# Altium schematic sheet imp002-evb-core.SchDoc
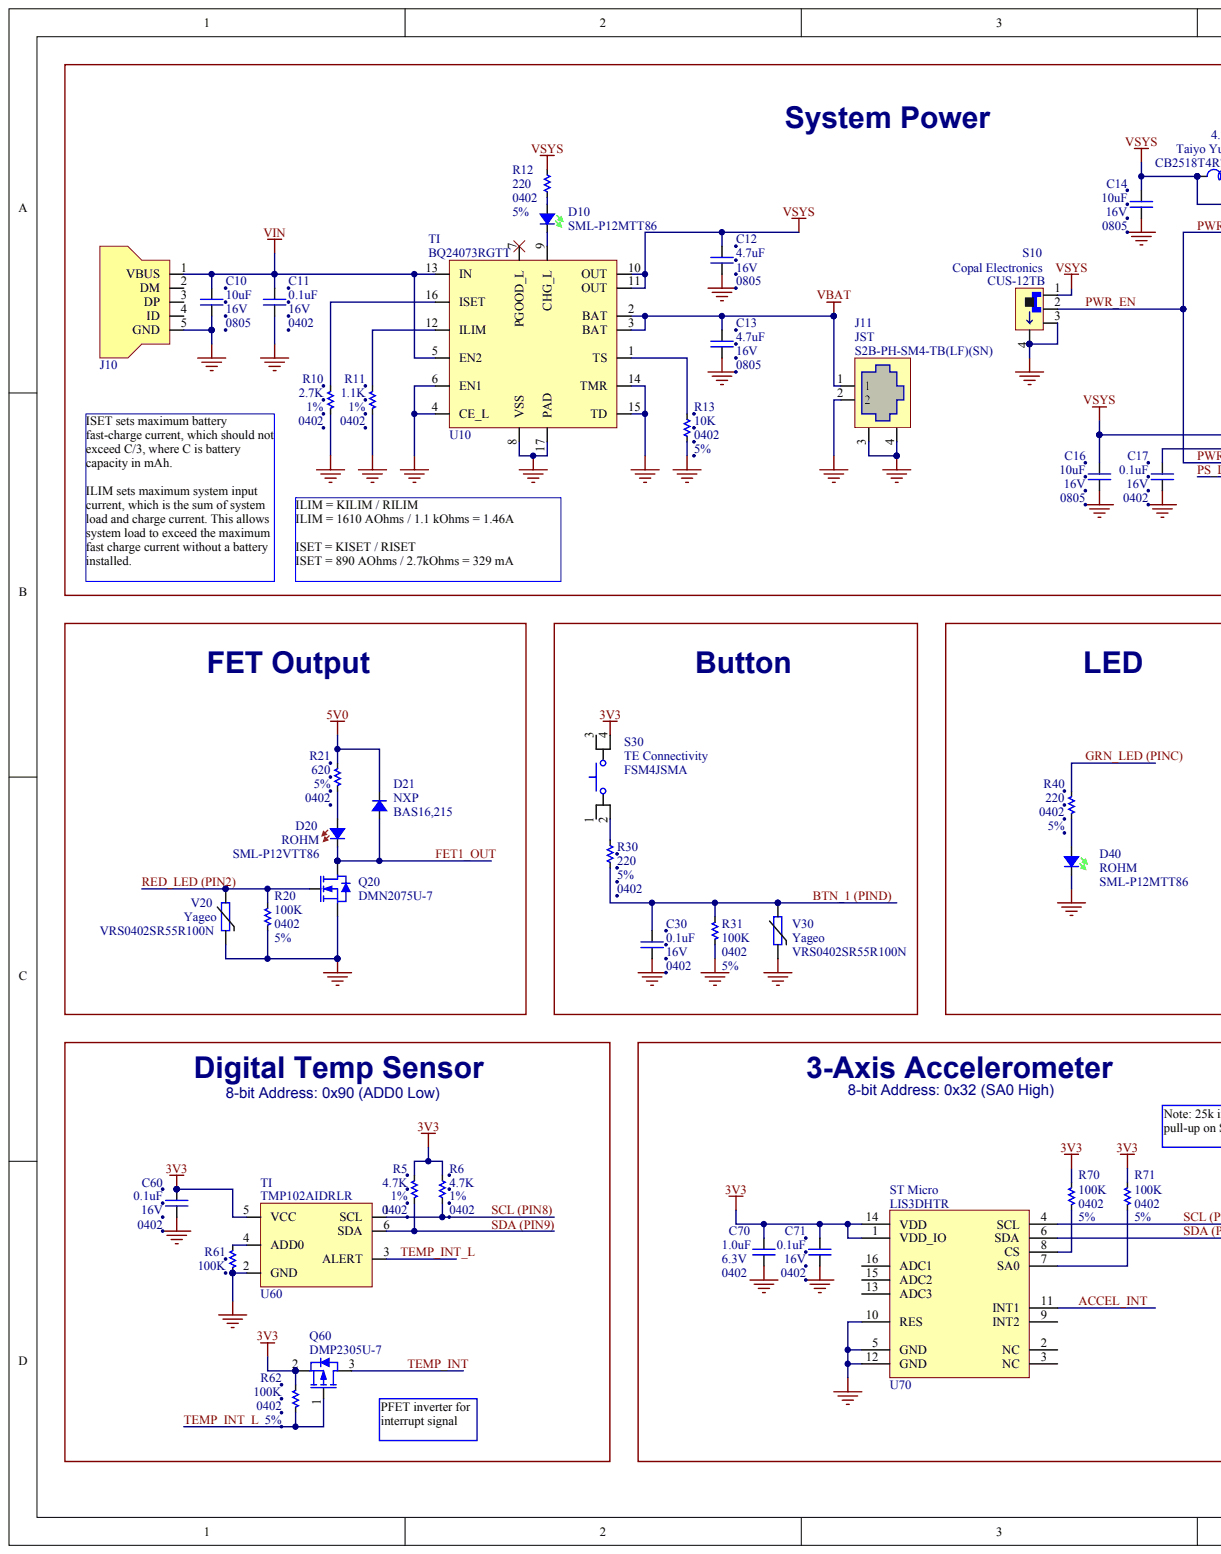
[diagram p0: imp002-evb-core.SchDoc, left]
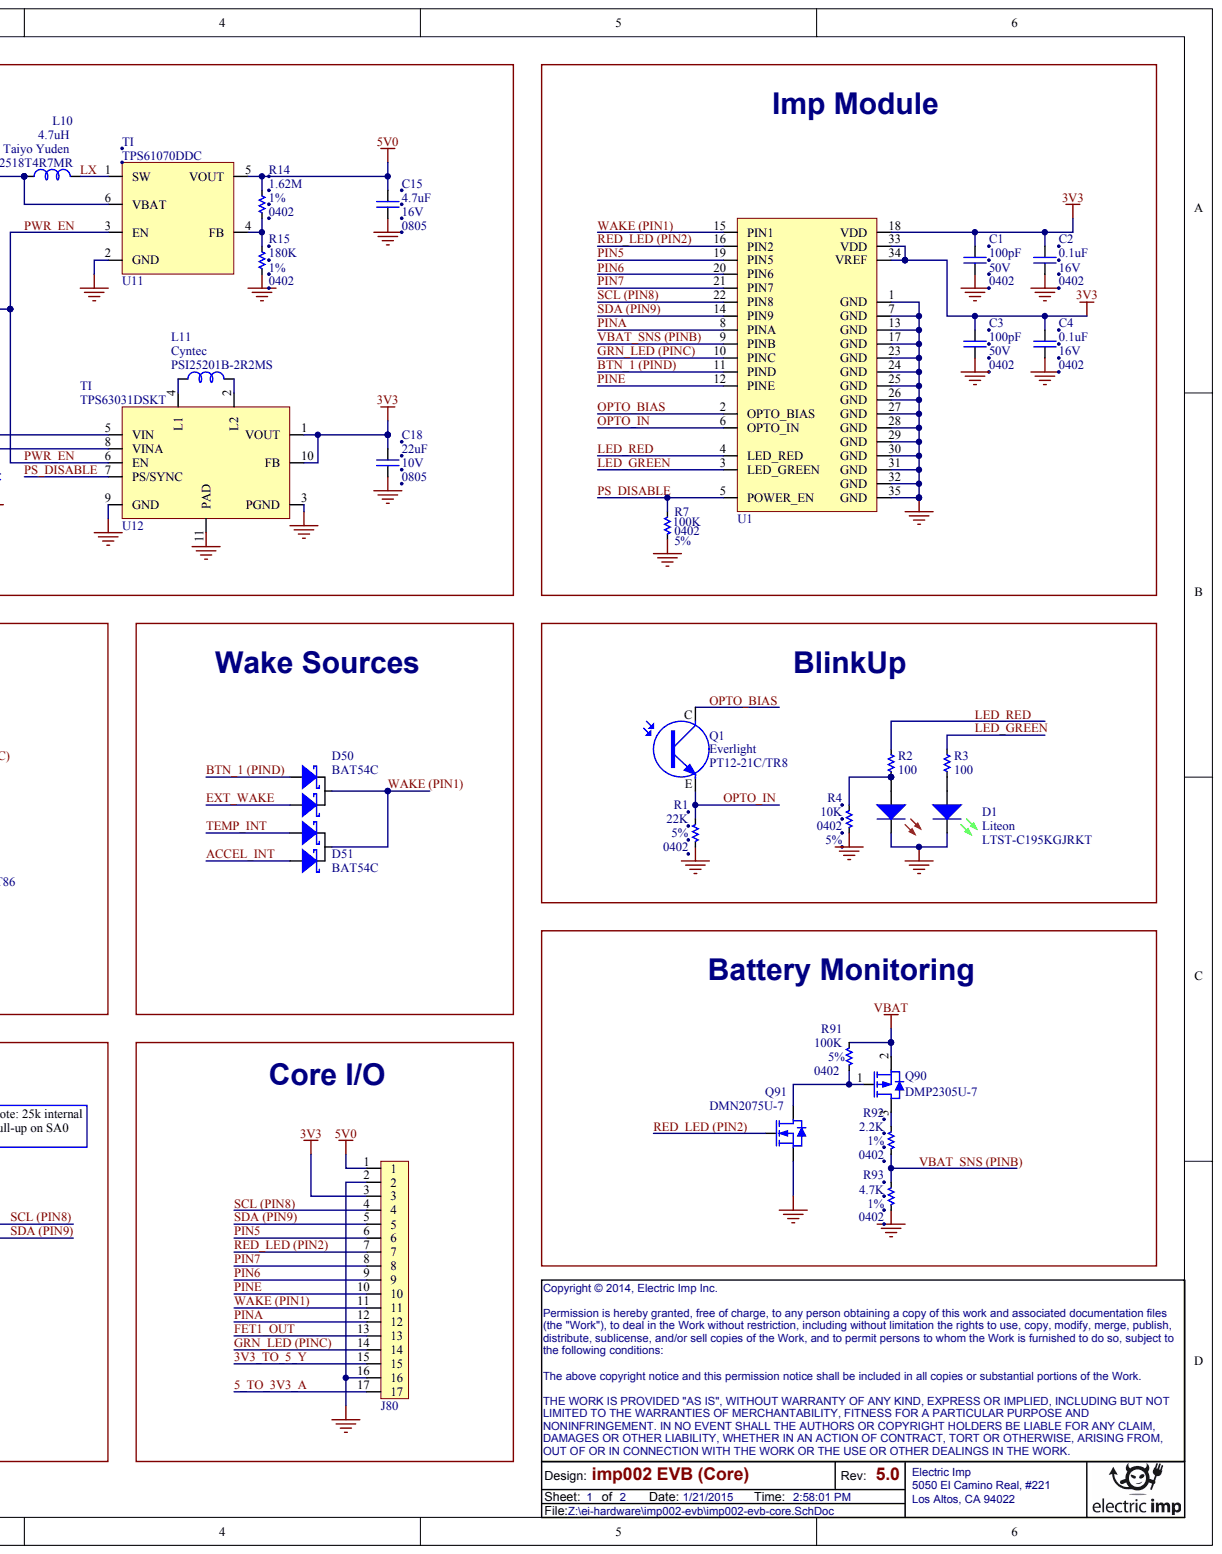
[diagram p1: imp002-evb-core.SchDoc, right]

## Parts
C1  value 100pF  Voltage: 50V  Package: 0402  (hidden comment: Ceramic Capacitor)
C2  value 0.1uF  Voltage: 16V  Package: 0402  (hidden comment: Ceramic Capacitor)
C3  value 100pF  Voltage: 50V  Package: 0402  (hidden comment: Ceramic Capacitor)
C4  value 0.1uF  Voltage: 16V  Package: 0402  (hidden comment: Ceramic Capacitor)
C10  value 10uF  Voltage: 16V  Package: 0805  (hidden comment: Ceramic Capacitor)
C11  value 0.1uF  Voltage: 16V  Package: 0402  (hidden comment: Ceramic Capacitor)
C12  value 4.7uF  Voltage: 16V  Package: 0805  (hidden comment: Ceramic Capacitor)
C13  value 4.7uF  Voltage: 16V  Package: 0805  (hidden comment: Ceramic Capacitor)
C14  value 10uF  Voltage: 16V  Package: 0805  (hidden comment: Ceramic Capacitor)
C15  value 4.7uF  Voltage: 16V  Package: 0805  (hidden comment: Ceramic Capacitor)
C16  value 10uF  Voltage: 16V  Package: 0805  (hidden comment: Ceramic Capacitor)
C17  value 0.1uF  Voltage: 16V  Package: 0402  (hidden comment: Ceramic Capacitor)
C18  value 22uF  Voltage: 10V  Package: 0805  (hidden comment: Ceramic Capacitor)
C30  value 0.1uF  Voltage: 16V  Package: 0402  (hidden comment: Ceramic Capacitor)
C60  value 0.1uF  Voltage: 16V  Package: 0402  (hidden comment: Ceramic Capacitor)
C70  value 1.0uF  Voltage: 6.3V  Package: 0402  (hidden comment: Ceramic Capacitor)
C71  value 0.1uF  Voltage: 16V  Package: 0402  (hidden comment: Ceramic Capacitor)
D1  Manufacturer: Liteon  Manufacturer Part Number: LTST-C195KGJRKT  (hidden comment: LED Red and Green)
D10  Package: 0402  Manufacturer Part Number: SML-P12MTT86  (hidden comment: LED Green)
D20  Manufacturer: ROHM  Manufacturer Part Number: SML-P12VTT86  (hidden comment: LED Red)
D21  Manufacturer: NXP  Manufacturer Part Number: BAS16,215  (hidden comment: Diode)
D40  Manufacturer: ROHM  Manufacturer Part Number: SML-P12MTT86  (hidden comment: LED Green)
D50  Manufacturer Part Number: BAT54C  (hidden comment: Dual Schottky Diode)
D51  Manufacturer Part Number: BAT54C  (hidden comment: Dual Schottky Diode)
J10  USB Mini/Micro-B  (value not drawn)
J11  Manufacturer: JST  Manufacturer Part Number: S2B-PH-SM4-TB(LF)(SN)  (hidden comment: JST Connector)
J80  Header  (value not drawn)
L10  value 4.7uH  Manufacturer: Taiyo Yuden  Manufacturer Part Number: CB2518T4R7MR  (hidden comment: Inductor)
L11  Manufacturer: Cyntec  Manufacturer Part Number: PSI25201B-2R2MS  (hidden comment: Inductor)
Q1  Manufacturer: Everlight  Manufacturer Part Number: PT12-21C/TR8  (hidden comment: PHOTOTRANSISTOR)
Q20  Manufacturer Part Number: DMN2075U-7  (hidden comment: N-Channel MOSFET)
Q60  PFET  Manufacturer Part Number: DMP2305U-7
Q90  Manufacturer Part Number: DMP2305U-7  (hidden comment: PFET)
Q91  Manufacturer Part Number: DMN2075U-7  (hidden comment: N-Channel MOSFET)
R1  value 22K  Package: 0402  Tolerance: 5%  (hidden comment: Resistor)
R2  value 100  (hidden comment: Resistor)
R3  value 100  (hidden comment: Resistor)
R4  value 10K  Package: 0402  Tolerance: 5%  (hidden comment: Resistor)
R5  value 4.7K  Package: 0402  Tolerance: 1%  (hidden comment: Resistor)
R6  value 4.7K  Package: 0402  Tolerance: 1%  (hidden comment: Resistor)
R7  value 100K  Package: 0402  Tolerance: 5%  (hidden comment: Resistor)
R10  value 2.7K  Package: 0402  Tolerance: 1%  (hidden comment: Resistor)
R11  value 1.1K  Package: 0402  Tolerance: 1%  (hidden comment: Resistor)
R12  value 220  Package: 0402  (hidden comment: Resistor)
R13  value 10K  Package: 0402  Tolerance: 5%  (hidden comment: Resistor)
R14  value 1.62M  Package: 0402  Tolerance: 1%  (hidden comment: Resistor)
R15  value 180K  Package: 0402  Tolerance: 1%  (hidden comment: Resistor)
R20  value 100K  Package: 0402  Tolerance: 5%  (hidden comment: Resistor)
R21  value 620  Package: 0402  Tolerance: 5%  (hidden comment: Resistor)
R30  value 220  Package: 0402  Tolerance: 5%  (hidden comment: Resistor)
R31  value 100K  Package: 0402  Tolerance: 5%  (hidden comment: Resistor)
R40  value 220  Package: 0402  Tolerance: 5%  (hidden comment: Resistor)
R61  value 100K  (hidden comment: Resistor)
R62  value 100K  Package: 0402  Tolerance: 5%  (hidden comment: Resistor)
R70  value 100K  Package: 0402  Tolerance: 5%  (hidden comment: Resistor)
R71  value 100K  Package: 0402  Tolerance: 5%  (hidden comment: Resistor)
R91  value 100K  Package: 0402  Tolerance: 5%  (hidden comment: Resistor)
R92  value 2.2K  Package: 0402  Tolerance: 1%  (hidden comment: Resistor)
R93  value 4.7K  Package: 0402  Tolerance: 1%  (hidden comment: Resistor)
S10  Manufacturer: Copal Electronics  Manufacturer Part Number: CUS-12TB  (hidden comment: Slide Switch)
S30  Manufacturer: TE Connectivity  Manufacturer Part Number: FSM4JSMA  (hidden comment: Push Button)
U1  imp module  (value not drawn)
U10  BQ24073  Manufacturer: TI  Manufacturer Part Number: BQ24073RGTT
U11  Manufacturer: TI  Manufacturer Part Number: TPS61070DDC  (hidden comment: Boost)
U12  TPS63031  Manufacturer: TI  Manufacturer Part Number: TPS63031DSKT
U60  TMP102  Manufacturer: TI  Manufacturer Part Number: TMP102AIDRLR
U70  LIS3DHTR  Manufacturer: ST Micro
V20  Manufacturer: Yageo  Manufacturer Part Number: VRS0402SR55R100N  (hidden comment: VARISTOR)
V30  Manufacturer: Yageo  Manufacturer Part Number: VRS0402SR55R100N  (hidden comment: VARISTOR)

## Nets
3V3: C1.2 C2.2 C3.2 C4.2 C18.2 C60.2 C70.2 C71.2 J80.3 Q60.2 R5.1 R6.1 R62.1 R70.2 R71.2 S30.4 U1.18(VDD) U1.33(VDD) U1.34(VREF) U12.1(VOUT) U12.10(FB) U60.5(VCC) U70.1(VDD_IO) U70.14(VDD)
5V0: C15.2 D21.K J80.1 R14.1 R21.1 U11.5(VOUT)
GND: C1.1 C2.1 C3.1 C4.1 C10.1 C11.1 C12.1 C13.1 C14.1 C15.1 C16.1 C17.1 C18.1 C30.1 C60.1 C70.1 C71.1 D1.KG D1.KR D40.K J10.5(GND) J11.2 J11.3 J11.4 J80.2 J80.16 Q20.2 Q91.2 R1.2 R4.2 R7.1 R10.2 R11.2 R13.2 R15.2 R20.1 R31.1 R61.2 R93.2 S10.3 S10.4 U1.1(GND) U1.7(GND) U1.13(GND) U1.17(GND) U1.23(GND) U1.24(GND) U1.25(GND) U1.26(GND) U1.27(GND) U1.28(GND) U1.29(GND) U1.30(GND) U1.31(GND) U1.32(GND) U1.35(GND) U10.4(CE_L) U10.6(EN1) U10.8(VSS) U10.14(TMR) U10.15(TD) U10.17(PAD) U11.2(GND) U12.3(PGND) U12.9(GND) U12.11(PAD) U60.2(GND) U70.5(GND) U70.10(RES) U70.12(GND) V20.2 V30.2
VBAT: C13.2 J11.1 Q90.2 R91.1 U10.2(BAT) U10.3(BAT)
VIN: C10.2 C11.2 J10.1(VBUS) U10.5(EN2) U10.13(IN)
VSYS: C12.2 C14.2 C16.2 L10.1 R12.2 S10.1 U10.10(OUT) U10.11(OUT) U11.6(VBAT) U12.5(VIN)
3V3_TO_5_Y: J80.15
5_TO_3V3_A: J80.17
ACCEL_INT: D51.2 U70.11(INT1)
BTN_1 (PIND): C30.2 D50.1 R30.2 R31.2 U1.11(PIND) V30.1
EXT_WAKE: D50.2
FET1_OUT: D20.K D21.A J80.13 Q20.3
GRN_LED (PINC): J80.14 R40.1 U1.10(PINC)
LED_GREEN: R3.1 U1.3(LED_GREEN)
LED_RED: R2.1 U1.4(LED_RED)
LX: L10.2 U11.1(SW)
OPTO_BIAS: Q1.C U1.2(OPTO_BIAS)
OPTO_IN: Q1.E R1.1 U1.6(OPTO_IN)
PIN5: J80.6 U1.19(PIN5)
PIN6: J80.9 U1.20(PIN6)
PIN7: J80.8 U1.21(PIN7)
PINA: J80.12 U1.8(PINA)
PINE: J80.10 U1.12(PINE)
PS_DISABLE: R7.2 U1.5(POWER_EN) U12.7(PS/SYNC)
PWR_EN: S10.2 U11.3(EN) U12.6(EN)
RED_LED (PIN2): J80.7 Q20.1 Q91.1 R20.2 U1.16(PIN2) V20.1
SCL (PIN8): J80.4 R6.2 U1.22(PIN8) U60.1(SCL) U70.4(SCL)
SDA (PIN9): J80.5 R5.2 U1.14(PIN9) U60.6(SDA) U70.6(SDA)
TEMP_INT: D51.1 Q60.3
TEMP_INT_L: Q60.1 R62.2 U60.3(ALERT)
VBAT_SNS (PINB): R92.2 R93.1 U1.9(PINB)
WAKE (PIN1): D50.3 D51.3 J80.11 U1.15(PIN1)
NetC17_2: C17.2 U12.8(VINA)
NetD1_AG: D1.AG R3.2
NetD1_AR: D1.AR R2.2 R4.1
NetD10_A: D10.A R12.1
NetD10_K: D10.K U10.9(CHG_L)
NetD20_A: D20.A R21.2
NetD40_A: D40.A R40.2
NetL11_1: L11.1 U12.4(L1)
NetL11_2: L11.2 U12.2(L2)
NetQ90_1: Q90.1 Q91.3 R91.2
NetQ90_3: Q90.3 R92.1
NetR10_1: R10.1 U10.16(ISET)
NetR11_1: R11.1 U10.12(ILIM)
NetR13_1: R13.1 U10.1(TS)
NetR14_2: R14.2 R15.1 U11.4(FB)
NetR30_1: R30.1 S30.2
NetR61_1: R61.1 U60.4(ADD0)
NetR70_1: R70.1 U70.8(CS)
NetR71_1: R71.1 U70.7(SA0)

Not connected: J10 2(DM) 3(DP) 4(ID); S30 1 3; U10 7(PGOOD_L); U70 2(NC) 3(NC) 9(INT2) 13(ADC3) 15(ADC2) 16(ADC1)

## Library data of the parts
C1 C3 = (no symbol name): Part Number: CAPC00043; Manufacturer: Yageo; Manufacturer Part Number: CC0402JRNPO9BN101; Tolerance: 5%; Dielectric: C0G; Manufacturer 2: Samsung; Manufacturer Part Number 2: CL05C101JB5NNNC; Supplier 1: Digi-Key; Supplier Part Number 1: 311-1024-1-ND; Supplier Price 1: 0.00324; Supplier 2: Digi-Key; Supplier Part Number 2: 1276-1025-1-ND; Supplier Price 2: 0.00324; Stock Quantity: 500; Stock Date: 3/12/2013
C2 C4 C11 C17 C30 C60 C71 = (no symbol name): Part Number: CAPC00025; Manufacturer: Samsung; Manufacturer Part Number: CL05B104KO5NNNC; Tolerance: 10%; Dielectric: X7R; Manufacturer 2: Taiyo Yuden; Manufacturer Part Number 2: EMK105B7104KV-F; Supplier 1: Digi-Key; Supplier Part Number 1: 1276-1001-1-ND; Supplier Price 1: 0.0039; Supplier 2: Digi-Key; Supplier Part Number 2: 587-1451-1-ND; Supplier Price 2: 0.0045; Stock Quantity: 1000; Stock Date: 3/12/2013
C10 C14 C16 = (no symbol name): Part Number: CAPC00037; Manufacturer: Samsung; Manufacturer Part Number: CL21A106KOQNNNE; Tolerance: 10%; Dielectric: X5R; Manufacturer 2: Taiyo Yuden; Manufacturer Part Number 2: EMK212BJ106KG-T; Supplier 1: Digi-Key; Supplier Part Number 1: 1276-1096-1-ND; Supplier Price 1: 0.0385; Supplier 2: Digi-Key; Supplier Part Number 2: 587-1295-1-ND; Supplier Price 2: 0.05355; Stock Quantity: 1000; Stock Date: 3/12/2013
C12 C13 C15 = (no symbol name): Part Number: CAPC00034; Manufacturer: Taiyo Yuden; Manufacturer Part Number: EMK212BJ475KG-T; Tolerance: 10%; Dielectric: X5R; Manufacturer 2: TDK; Manufacturer Part Number 2: C2012X5R1C475K/1.25; Supplier 1: Digi-Key; Supplier Part Number 1: 587-1294-1-ND; Supplier Price 1: 0.051; Supplier 2: Digi-Key; Supplier Part Number 2: 445-7640-1-ND; Supplier Price 2: 0.051; Stock Quantity: 1000; Stock Date: 3/12/2013
C18 = (no symbol name): Part Number: CAPC00107; Manufacturer: TDK; Manufacturer Part Number: C2012X5R1A226M125AB; Tolerance: 20%; Dielectric: X5R; Manufacturer 2: Samsung; Manufacturer Part Number 2: CL21A226MPQNNNE; Supplier 1: Digi-Key; Supplier Part Number 1: 445-7665-1-ND; Supplier Price 1: 0.1495; Supplier 2: Digi-Key; Supplier Part Number 2: 1276-2910-1-ND; Supplier Price 2: 0.1495
C70 = (no symbol name): Part Number: CAPC00005; Manufacturer: Murata; Manufacturer Part Number: GRM155R60J105KE19D; Tolerance: 10%; Dielectric: X5R; Supplier 1: Digi-Key; Supplier Part Number 1: 490-1320-1-ND; Supplier Price 1: 0.0087; Manufacturer 2: Samsung; Manufacturer Part Number 2: CL05A105KQ5NNNC; Supplier 2: Digi-Key; Supplier Part Number 2: 1276-1010-1-ND; Supplier Price 2: 0.009; Stock Date: 3/12/2013; Stock Quantity: 1000
D1 = (no symbol name): Part Number: LED00012; Color: Red/Green; Millicandela Rating (min): 25mcd, 35mcd; Voltage - Forward (Vf) Typ: 2V, 2V; Current - Test: 20mA; Wavelength - Dominant: 631nm, 571nm; Wavelength - Peak: 639nm, 574nm; Viewing Angle: 130°; Lens Type: Clear; Package: SMT; Supplier 1: Digi-Key; Supplier Part Number 1: 160-1452-1-ND; Supplier Price 1: 0.1206; Stock Quantity: 4; Stock Date: 2/27/2013; ComponentLink1URL: http://media.digikey.com/pdf/Data%20Sheets/Lite-On%20PDFs/LTST-C195KGJRKT.pdf
D10 = (no symbol name): Part Number: LED00034; Manufacturer: ROHM; Millicandela Rating (min): 35mcd; Voltage - Forward (Vf) Typ: 2.2V; Current - Test: 20mA; Wavelength - Dominant: 572nm; Viewing Angle: 120°; Lens Type: Clear; Color: Green; Wavelength - Peak: -; Supplier 1: Digi-Key; Supplier Part Number 1: 511-1591-1-ND; Supplier Price 1: 0.126; Stock Quantity: 9; Stock Date: 7/15/2013; ComponentLink1URL: http://www.digikey.com/product-detail/en/SML-P12MTT86/511-1591-1-ND/1643123
D20 = (no symbol name): Part Number: LED00033; Millicandela Rating (min): 60mcd; Voltage - Forward (Vf) Typ: 2V; Current - Test: 20mA; Wavelength - Dominant: 630nm; Viewing Angle: 120°; Lens Type: Clear; Package: 0402; Color: Red; Wavelength - Peak: -; Supplier 1: Digi-Key; Supplier Part Number 1: 511-1594-1-ND; Supplier Price 1: 0.126; Stock Quantity: 9; Stock Date: 7/15/2013; ComponentLink1URL: http://www.digikey.com/product-detail/en/SML-P12VTT86/511-1594-1-ND/1643126
D21 = (no symbol name): Part Number: DIODE00003; Voltage - Forward (Vf) (Max) @ If: 1.25V @150mA; Current - Forward DC: 215mA; Current - Reverse Leakage @ Vr: 0.5uA @ 80V; Continuous Reverse Voltage: 100V; Power - Max: 250mW; Package: SOT23; Supplier 1: Digi-Key; Supplier Part Number 1: 568-1596-1-ND; Supplier Price 1: 0.02688; Stock Quantity: 25; Stock Date: 3/4/2013; ComponentLink1URL: http://www.nxp.com/documents/data_sheet/BAS16_SER.pdf
D40 = (no symbol name): Part Number: LED00034; Millicandela Rating (min): 35mcd; Voltage - Forward (Vf) Typ: 2.2V; Current - Test: 20mA; Wavelength - Dominant: 572nm; Viewing Angle: 120°; Lens Type: Clear; Package: 0402; Color: Green; Wavelength - Peak: -; Supplier 1: Digi-Key; Supplier Part Number 1: 511-1591-1-ND; Supplier Price 1: 0.126; Stock Quantity: 9; Stock Date: 7/15/2013; ComponentLink1URL: http://www.digikey.com/product-detail/en/SML-P12MTT86/511-1591-1-ND/1643123
D50 D51 = (no symbol name): Part Number: SCHOTTKY00009; Manufacturer: Fairchild; Diode Type: Schottky, Dual; Voltage - DC Reverse (Vr) (Max): 30V; Current - Average Rectified (Io): 200mA; Voltage - Forward (Vf) (Max) @ If: 800mV @ 100mA; Package: SOT-23; Supplier 1: Digi-key; Supplier Part Number 1: BAT54CFSCT-ND; Supplier Price 1: 0.03986; ComponentLink1URL: http://www.digikey.com/product-detail/en/BAT54C/BAT54CFSCT-ND/458929
J10 = (no symbol name): Part Number: USB00005; Manufacturer: FCI; Manufacturer Part Number: 10118192-0001LF; Supplier 1: Digi-Key; Supplier Part Number 1: 609-4613-1-ND; Supplier Price 1: 0.25988; ComponentLink1URL: http://www.digikey.com/product-detail/en/10118192-0001LF/609-4613-1-ND/2785378
J11 = (no symbol name): Part Number: CON00017; Supplier 1: Digi-Key; Supplier Part Number 1: 455-1749-1-ND; Supplier Price 1: 0.34734; ComponentLink1URL: http://www.digikey.com/product-detail/en/S2B-PH-SM4-TB(LF)(SN)/455-1749-1-ND/926846
J80 = (no symbol name): Part Number: HDR00042; Manufacturer: Samtec; Manufacturer Part Number: SSQ-117-03-G-S; Gender: Female; Connector Type: Socket; Number of Columns: 17; Pitch: 2.54mm; Number of Rows: 1; Number of Positions Loaded: 17; Mounting Type: Through Hole; Orientation: Vertical; Contact Finish: Gold Flash; Supplier 1: Digi-Key; Supplier Part Number 1: SAM1198-17-ND; Supplier Price 1: 2.18549
L10 = (no symbol name): Part Number: IND00044; Current: 1.2A; Current - Saturation: 310mA; Tolerance: 20%; DC Resistance (DCR): 130 mOhm (Max); Package: 1007; Current - Temperature Rise: -; Supplier 1: Digi-Key; Supplier Part Number 1: 587-2187-1-ND; Supplier Price 1: 0.0765; ComponentLink1URL: http://www.digikey.com/product-detail/en/CB2518T4R7MR/587-2187-1-ND/2002885
L11 = (no symbol name): Part Number: IND00001; Value: 2.2uH; Current: 1.3A; Current - Saturation: 2.0A; Tolerance: 20%; DC Resistance (DCR): 155 mOhm; Package: 2520; Current - Temperature Rise: 1.3A; Manufacturer 2: Sumida; Manufacturer Part Number 2: 252012CDMCDS-2R2MC; Supplier 1: Cyntec; Supplier Part Number 1: PSI25201B-2R2MS; Supplier Price 1: 0.05; Supplier 2: Digi-Key; Supplier Part Number 2: 308-2344-1-ND; Supplier Price 2: 0.1679; ComponentLink1URL: http://www.cyntec.com/product/sealed_choke/download/PSI25201B.pdf
Q1 = (no symbol name): Part Number: PHOTO00004; Lens Type: Clear; Package: SMT; Supplier 1: Digi-Key; Supplier Part Number 1: 1080-1378-1-ND; Supplier Price 1: 0.07825; Stock Quantity: 50; Stock Date: 4/19/2013; ComponentLink1URL: http://www.digikey.com/product-detail/en/PT12-21C%2FTR8/1080-1378-1-ND/2676112
Q20 Q91 = (no symbol name): Part Number: NFET00004; Manufacturer: Diodes Incorporated; Drain to Source Voltage (Vdss): 20V; Current - Continuous Drain (Id) @ 25° C: 4.2A; Rds On (Max) @ Id, Vgs: 45 mOhm @ 3.1A, 2.5V; Vgs(th) (Max) @ Id: 1.0V @ 250uA; Power - Max: 800mW; Package: SOT23; Supplier 1: Digi-Key; Supplier Part Number 1: DMN2075U-7DICT-ND; Supplier Price 1: 0.08295; Stock Quantity: 41; Stock Date: 3/5/2013; ComponentLink1URL: http://www.diodes.com/datasheets/ds31837.pdf
Q60 Q90 = (no symbol name): Part Number: PFET00003; Manufacturer: Diodes Inc; Drain to Source Voltage (Vdss): 20V; Current - Continuous Drain (Id) @ 25° C: 4.2A; Rds On (Max) @ Id, Vgs: 60 mOhm @ 4.2A, 4.5V; Vgs(th) (Max) @ Id: 900mV @ 250µA; Power - Max: 1.4W; Supplier 1: Digi-key; Supplier Part Number 1: DMP2305UDICT-ND; Supplier Price 1: 0.08532; ComponentLink1URL: http://www.diodes.com/datasheets/ds31737.pdf
R1 = (no symbol name): Part Number: RES00007; Manufacturer: Yageo; Manufacturer Part Number: RC0402JR-0722KL; Power: 0.063W; Manufacturer 2: Panasonic; Manufacturer Part Number 2: ERJ-2GEJ223X; Supplier 1: Digi-Key; Supplier Part Number 1: 311-22KJRCT-ND; Supplier Price 1: 0.00237; Supplier 2: Digi-Key; Supplier Part Number 2: P22KJCT-ND; Supplier Price 2: 0.0048
R2 R3 = (no symbol name): Part Number: RES00024; Manufacturer: Yageo; Manufacturer Part Number: RC0402FR-07100RL; Tolerance: 1%; Power: 0.063W; Package: 0402; Manufacturer 2: ROHM; Manufacturer Part Number 2: MCR01MRTF1000; Supplier 1: Digi-Key; Supplier Part Number 1: 311-100LRCT-ND; Supplier Price 1: 0.0027; Supplier 2: Digi-Key; Supplier Part Number 2: RHM100CDCT-ND; Supplier Price 2: 0.0048; Stock Date: 4/5/2013; Stock Quantity: 1000
R4 R13 = (no symbol name): Part Number: RES00006; Manufacturer: Stackpole; Manufacturer Part Number: RMCF0402JT10K0; Power: 0.063W; Manufacturer 2: ROHM; Manufacturer Part Number 2: MCR01MRTJ103; Supplier 1: Digi-Key; Supplier Part Number 1: RMCF0402JT10K0CT-ND; Supplier Price 1: 0.00256; Supplier 2: Digi-Key; Supplier Part Number 2: RHM10KCECT-ND; Supplier Price 2: 0.00352; Stock Quantity: 120; Stock Date: 4/19/2013
R5 R6 R93 = (no symbol name): Part Number: RES00038; Manufacturer: Yageo; Manufacturer Part Number: RC0402FR-134K7L; Power: 0.063W; Manufacturer 2: Stackpole; Manufacturer Part Number 2: RMCF0402FT4K70; Supplier 1: Digi-Key; Supplier Part Number 1: 311-4.7KPFCT-ND; Supplier Price 1: 0.0027; Supplier 2: Digi-Key; Supplier Part Number 2: RMCF0402FT4K70CT-ND; Supplier Price 2: 0.00384; Stock Quantity: 1000; Stock Date: 4/5/2013
R7 R20 R31 R62 R70 R71 R91 = (no symbol name): Part Number: RES00001; Manufacturer: Samsung; Manufacturer Part Number: RC1005J104CS; Power: 0.063W; Manufacturer 2: ROHM; Manufacturer Part Number 2: MCR01MRTJ104; Supplier 1: Digi-Key; Supplier Part Number 1: 1276-4424-1-ND; Supplier Price 1: 0.0023; Supplier 2: Digi-Key; Supplier Part Number 2: HM100KCECT-ND; Supplier Price 2: 0.00233; Stock Date: 4/5/2013; Stock Quantity: 2100
R10 = (no symbol name): Part Number: RES00098; Manufacturer: Rohm; Manufacturer Part Number: MCR01MRTF2701; Power: 0.063W; Manufacturer 2: Yageo; Manufacturer Part Number 2: RC0402FR-072K7L; Supplier 1: Digi-Key; Supplier Part Number 1: RHM2.70KCDCT-ND; Supplier Price 1: 0.00233; Supplier 2: Digi-Key; Supplier Part Number 2: 311-2.70KLRCT-ND; Supplier Price 2: 0.00268
R11 = (no symbol name): Part Number: RES00097; Manufacturer: Bourns; Manufacturer Part Number: CR0402-FX-1101GLF; Power: 0.063W; Manufacturer 2: Rohm; Manufacturer Part Number 2: MCR01MRTF1101; Supplier 1: Digi-Key; Supplier Part Number 1: CR0402-FX-1101GLFCT-ND; Supplier Price 1: 0.01503; Supplier 2: Digi-Key; Supplier Part Number 2: RHM1.1KCDCT-ND; Supplier Price 2: 0.00247
R12 = (no symbol name): Part Number: RES00011; Manufacturer: Yageo; Manufacturer Part Number: RC0402JR-07220RL; Tolerance: 5%; Power: 0.063W; Manufacturer 2: Panasonic; Manufacturer Part Number 2: ERJ-2GEJ221X; Supplier 1: Digi-Key; Supplier Part Number 1: 311-220JRCT-ND; Supplier Price 1: 0.00237; Supplier 2: Digi-Key; Supplier Part Number 2: P220JCT-ND; Supplier Price 2: 0.0048
R14 = (no symbol name): Part Number: RES00099; Manufacturer: Vishay Dale; Manufacturer Part Number: CRCW04021M62FKED; Power: 0.063W; Supplier 1: Digi-Key; Supplier Part Number 1: 541-1.62MLCT-ND; Supplier Price 1: 0.0174
R15 = (no symbol name): Part Number: RES00092; Manufacturer: Yageo; Manufacturer Part Number: RC0402FR-07180KL; Power: 0.063W; Manufacturer 2: ROHM; Manufacturer Part Number 2: MCR01MRTF1803; Supplier 1: Digi-Key; Supplier Part Number 1: 311-180KLRCT-ND; Supplier Price 1: 0.00268; Supplier 2: Digi-Key; Supplier Part Number 2: RHM180KCDCT-ND; Supplier Price 2: 0.00247
R21 = (no symbol name): Part Number: RES00048; Manufacturer: Samsung; Manufacturer Part Number: RC1005J621CS; Power: 0.063W; Manufacturer 2: Yageo; Manufacturer Part Number 2: RC0402JR-07620RL; Supplier 1: Digi-Key; Supplier Part Number 1: 1276-4371-1-ND; Supplier Price 1: 0.0023; Supplier 2: Digi-Key; Supplier Part Number 2: 311-620JRCT-ND; Supplier Price 2: 0.00237; Stock Quantity: 100; Stock Date: 4/5/2013
R30 R40 = (no symbol name): Part Number: RES00011; Manufacturer: Yageo; Manufacturer Part Number: RC0402JR-07220RL; Power: 0.063W; Manufacturer 2: Panasonic; Manufacturer Part Number 2: ERJ-2GEJ221X; Supplier 1: Digi-Key; Supplier Part Number 1: 311-220JRCT-ND; Supplier Price 1: 0.00237; Supplier 2: Digi-Key; Supplier Part Number 2: P220JCT-ND; Supplier Price 2: 0.0048
R61 = (no symbol name): Part Number: RES00001; Manufacturer: Samsung; Manufacturer Part Number: RC1005J104CS; Tolerance: 5%; Power: 0.063W; BOMOPTION: DNS; Package: 0402; Manufacturer 2: ROHM; Manufacturer Part Number 2: MCR01MRTJ104; Supplier 1: Digi-Key; Supplier Part Number 1: 1276-4424-1-ND; Supplier Price 1: 0.0023; Supplier 2: Digi-Key; Supplier Part Number 2: HM100KCECT-ND; Supplier Price 2: 0.00233; Stock Quantity: 2100; Stock Date: 4/5/2013
R92 = (no symbol name): Part Number: RES00037; Manufacturer: Stackpole; Manufacturer Part Number: RMCF0402FT2K20; Power: 0.063W; Manufacturer 2: Rohm; Manufacturer Part Number 2: MCR01MRTF2201; Supplier 1: Digi-Key; Supplier Part Number 1: RMCF0402FT2K20CT-ND; Supplier Price 1: 0.00384; Supplier 2: Digi-Key; Supplier Part Number 2: RHM2.20KCDCT-ND; Supplier Price 2: 0.0048; Stock Quantity: 1000; Stock Date: 4/2/2013
S10 = (no symbol name): Part Number: SWITCH00002; Supplier 1: Digi-Key; Supplier Part Number 1: 563-1102-1-ND; Supplier Price 1: 0.45778; ComponentLink1URL: http://www.digikey.com/product-detail/en/CUS-12TB/563-1102-1-ND/1124231
S30 = (no symbol name): Part Number: BTN00001; Supplier 1: Digi-Key; Supplier Part Number 1: 450-1129-ND; Supplier Price 1: 0.0917; ComponentLink1URL: http://www.digikey.com/product-detail/en/FSM4JSMATR/450-1759-1-ND/2271638
U1 = (no symbol name): Part Number: MOD00002; Manufacturer: Electric Imp; Manufacturer Part Number: IMP002
U10 = (no symbol name): Part Number: IC00173; Supplier 1: Digi-Key; Supplier Part Number 1: 296-23839-1-ND; Supplier Price 1: 2.1839; ComponentLink1URL: http://www.digikey.com/product-detail/en/BQ24073RGTT/296-23839-1-ND/1960945
U11 = (no symbol name): Part Number: IC00151; Supplier 1: Digi-Key; Supplier Part Number 1: 296-17151-1-ND; Supplier Price 1: 0.50625; ComponentLink1URL: http://www.digikey.com/product-detail/en/TPS61070DDCR/296-17151-1-ND/665930; MPN: TPS61070DDC
U12 = (no symbol name): Part Number: IC00174; Supplier 1: Digi-Key; Supplier Part Number 1: 296-23904-1-ND; Supplier Price 1: 2.2759; Supplier 2: Verical; Supplier Part Number 2: TPS63031DSKR; Supplier Price 2: 1.2534; ComponentLink1URL: http://www.digikey.com/product-detail/en/TPS63031DSKT/296-23904-1-ND/1967873
U60 = (no symbol name): Part Number: IC00103; Supplier 1: Digi-Key; Supplier Part Number 1: 296-26834-1-ND; Supplier Price 1: 0.68851; ComponentLink1URL: http://www.digikey.com/product-detail/en/TMP102AIDRLR/296-26834-1-ND/2255195
U70 = (no symbol name): Part Number: IC00038; Manufacturer Part Number: LIS3DHTR; Supplier 1: Digi-Key; Supplier Part Number 1: 497-10613-1-ND; Supplier Price 1: 1.09366; Supplier 2: Mouser; Supplier Part Number 2: 511-LIS3DHTR; Supplier Price 2: 1.06; ComponentLink1URL: http://www.st.com/internet/com/TECHNICAL_RESOURCES/TECHNICAL_LITERATURE/DATASHEET/CD00274221.pdf; Stock Date: 3/5/2013; Stock Quantity: 15
V20 V30 = (no symbol name): Part Number: VAR00001; Max VDC: 5.5V; Varistor Voltage: 10V; Imax: 10A; Supplier 1: Digi-Key; Supplier Part Number 1: 311-1515-1-ND; Supplier Price 1: 0.00529; ComponentLink1URL: http://www.digikey.com/product-detail/en/VRS0402SR55R100N/311-1515-1-ND/2833821
